# Altium schematic sheet Analog.SchDot(Analog)
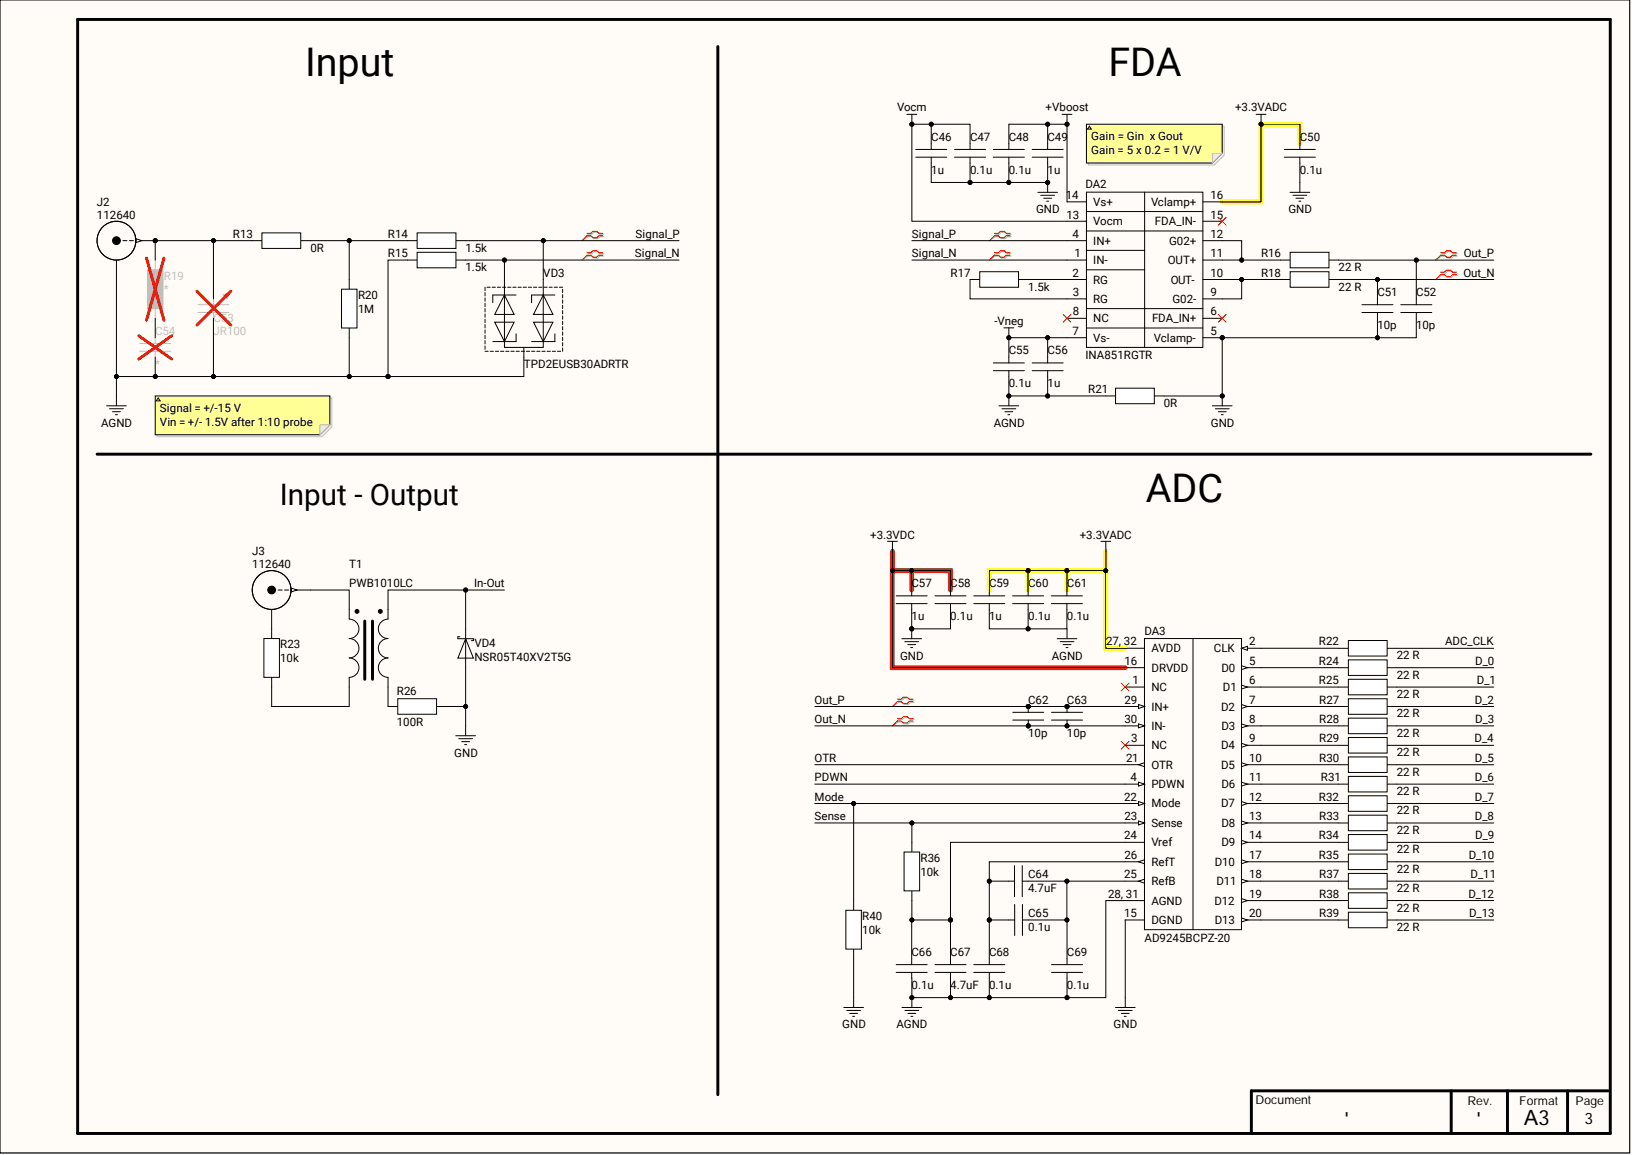
[diagram p0: Analog.SchDot(Analog)]

## Parts
C46  1u  [C0603]
C47  0.1u  [C0603]
C48  0.1u  [C0603]
C49  1u  [C0603]
C50  0.1u  [C0603]
C51  10p  [C0603]
C52  10p  [C0603]
C53  JR100  [Trimmer Capacitors]
C54  (no value)  [C0603]
C55  0.1u  [C0603]
C56  1u  [C0603]
C57  1u  [C0603]
C58  0.1u  [C0603]
C59  1u  [C0603]
C60  0.1u  [C0603]
C61  0.1u  [C0603]
C62  10p  [C0603]
C63  10p  [C0603]
C64  4.7uF  [C0603]
C65  0.1u  [C0603]
C66  0.1u  [C0603]
C67  4.7uF  [C0603]
C68  0.1u  [C0603]
C69  0.1u  [C0603]
DA2  INA851RGTR  [VQFN_16_INA851]
DA3  AD9245BCPZ-20  [LFCSP-32_AD9245]
J2  112640  [112640]
J3  112640  [112640]
R13  0R  [R0603]
R14  1.5k  [R0603]
R15  1.5k  [R0603]
R16  22 R  [R0603]
R17  1.5k  [R0603]
R18  22 R  [R0603]
R19  (no value)  [R0603]
R20  1M  [R0603]
R21  0R  [R0603]
R22  22 R  [R0603]
R23  10k  [R0603]
R24  22 R  [R0603]
R25  22 R  [R0603]
R26  100R  [R0603]
R27  22 R  [R0603]
R28  22 R  [R0603]
R29  22 R  [R0603]
R30  22 R  [R0603]
R31  22 R  [R0603]
R32  22 R  [R0603]
R33  22 R  [R0603]
R34  22 R  [R0603]
R35  22 R  [R0603]
R36  10k  [R0603]
R37  22 R  [R0603]
R38  22 R  [R0603]
R39  22 R  [R0603]
R40  10k  [R0603]
T1  PWB1010LC  [PWB1010]
VD3  TPD2EUSB30ADRTR  [SOT-9X3]
VD4  NSR05T40XV2T5G  [SOD-523-2]

## Nets
+3.3VADC: C50.2 C59.2 C60.2 C61.2 DA2.16(Vclamp+) DA3.27, 32(AVDD)
+3.3VDC: C57.2 C58.2 DA3.16(DRVDD)
+Vboost: C48.2 C49.2 DA2.14(Vs+)
-Vneg: C55.2 C56.2 DA2.7(Vs-)
AGND: C53.2 C54.2 C55.1 C56.1 C59.1 C60.1 C61.1 C66.1 C67.2 C68.1 C69.1 DA3.28, 31(AGND) J2.2 R15.1 R20.1 R21.1 VD3.3
GND: C46.1 C47.1 C48.1 C49.1 C50.1 C51.2 C52.2 C57.1 C58.1 DA2.5(Vclamp-) DA3.15(DGND) R21.2 R26.2 R40.1 VD4.1
Vocm: C46.2 C47.2 DA2.13(Vocm)
ADC_CLK: R22.2
D_0: R24.2
D_1: R25.2
D_2: R27.2
D_3: R28.2
D_4: R29.2
D_5: R30.2
D_6: R31.2
D_7: R32.2
D_8: R33.2
D_9: R34.2
D_10: R35.2
D_11: R37.2
D_12: R38.2
D_13: R39.2
In-Out: T1.6 VD4.2
Mode: DA3.22(Mode) R40.2
OTR: DA3.21(OTR)
Out_N: C51.1 C62.2 C63.2 DA3.30(IN-) R18.2
Out_P: C52.1 C62.1 C63.1 DA3.29(IN+) R16.2
PDWN: DA3.4(PDWN)
Sense: DA3.23(Sense) R36.2
Signal_N: DA2.1(IN-) R15.2 VD3.2
Signal_P: DA2.4(IN+) R14.2 VD3.1
NetC53_1: C53.1 J2.1 R13.1 R19.2
NetC54_1: C54.1 R19.1
NetC64_1: C64.1 C65.2 C68.2 DA3.26(RefT)
NetC64_2: C64.2 C65.1 C69.2 DA3.25(RefB)
NetC66_2: C66.2 C67.1 DA3.24(Vref) R36.1
NetDA2_2: DA2.2(RG) R17.2
NetDA2_3: DA2.3(RG) R17.1
NetDA2_9: DA2.9(G02-) DA2.10(OUT-) R18.1
NetDA2_11: DA2.11(OUT+) DA2.12(G02+) R16.1
NetDA3_2: DA3.2(CLK) R22.1
NetDA3_5: DA3.5(D0) R24.1
NetDA3_6: DA3.6(D1) R25.1
NetDA3_7: DA3.7(D2) R27.1
NetDA3_8: DA3.8(D3) R28.1
NetDA3_9: DA3.9(D4) R29.1
NetDA3_10: DA3.10(D5) R30.1
NetDA3_11: DA3.11(D6) R31.1
NetDA3_12: DA3.12(D7) R32.1
NetDA3_13: DA3.13(D8) R33.1
NetDA3_14: DA3.14(D9) R34.1
NetDA3_17: DA3.17(D10) R35.1
NetDA3_18: DA3.18(D11) R37.1
NetDA3_19: DA3.19(D12) R38.1
NetDA3_20: DA3.20(D13) R39.1
NetJ3_1: J3.1 T1.1
NetJ3_2: J3.2 R23.2
NetR13_2: R13.2 R14.1 R20.2
NetR23_1: R23.1 T1.3
NetR26_1: R26.1 T1.4

Not connected: DA2 6(FDA_IN+) 8(NC) 15(FDA_IN-); DA3 1(NC) 3(NC)

## Library data of the parts
C46 C49 C56 C57 C59 = MLCC: 25V 1uF X7R ±10% 0603 Multilayer Ceramic Capacitors MLCC - SMD/SMT ROHS; Manufacturer: IHHEC(HOLY STONE ENTERPRISE CO., LTD); P/N: C0603X105K025T; Case: 0603; Component Kind: Standard; Component Type: MLCC; ComponentLink1Description: Link; ComponentLink2Description: Datasheet; Value: 1u; Library Name: Scheet.SchLib
  C46 C56 C57 C59: ComponentLink1URL: https://eu.mouser.com/ProductDetail/Murata-Electronics/GCM155C71A105ME38D?qs=QzBtWTOodeV5ddl%2FvWs3LA%3D%3D; ComponentLink2URL: https://eu.mouser.com/datasheet/2/281/1/GCM155C71A105ME38_01A-3142248.pdf
  C49: ComponentLink1URL: https://www.lcsc.com/product-detail/Multilayer-Ceramic-Capacitors-span-style-background-color-ff0-MLCC-span-SMD-SMT_IHHEC-HOLY-STONE-ENTERPRISE-CO-LTD-C0603X105K025T_C559292.html; ComponentLink2URL: https://www.lcsc.com/datasheet/lcsc_datasheet_2304140030_IHHEC-HOLY-STONE-ENTERPRISE-CO---LTD-C0603X105K025T_C559292.pdf
C47 C48 C50 C55 C58 C60 C61 C65 C66 C68 C69 = MLCC: 100V 100nF X7R ±10% 0603 Multilayer Ceramic Capacitors MLCC - SMD/SMT ROHS; Manufacturer: Kyocera AVX; P/N: 06031C104K4T2A; Case: 0603; Component Kind: Standard; Component Type: MLCC; ComponentLink1Description: Link; ComponentLink2Description: Datasheet; Value: 0.1u; Library Name: Scheet.SchLib
C51 C52 C62 C63 = MLCC: 50V 10pF C0G 0603 Multilayer Ceramic Capacitors MLCC - SMD/SMT ROHS; Manufacturer: Kyocera AVX; P/N: 06035A100DAT2A; Case: 0603; Component Kind: Standard; Component Type: MLCC; ComponentLink1Description: Link; ComponentLink2Description: Datasheet; Value: 10p; Library Name: Scheet.SchLib
  C51 C52: ComponentLink1URL: https://eu.mouser.com/ProductDetail/KEMET/C0402C100K5RACTU?qs=Mv7BduZupUgEdPvwNAxLRQ%3D%3D; ComponentLink2URL: https://eu.mouser.com/datasheet/2/447/KEM_C1002_X7R_SMD-3316098.pdf
C53 = JR100: Trimmer/Variable Capacitors 2.0 - 10pF 100volts; Manufacturer: Knowles; Value: 2 pF to 10 pF; Case: JR; Component Kind: Standard; Component Type: Trimmer/Variable Capacitors; ComponentLink1Description: Datasheet; ComponentLink1URL: https://eu.mouser.com/datasheet/2/218/Knowles_Trimmers_Catalogue_web-1774092.pdf; ComponentLink2Description: Link; ComponentLink2URL: https://eu.mouser.com/ProductDetail/Knowles-Voltronics/JR100?qs=Xqu1B%2Fs4Uoag80ukOW5org%3D%3D; P/N: JR100; Library Name: Scheet.SchLib
C54 = MLCC: Cercap smd; Component Kind: Standard; ComponentLink1Description: Link; ComponentLink2Description: Datasheet; Library Name: Scheet.SchLib
C64 C67 = MLCC: 16V 4.7uF X7S ±10% 0603 Multilayer Ceramic Capacitors MLCC - SMD/SMT ROHS; Manufacturer: Murata Electronics; P/N: GRT188C71C475KE13D; Case: 0603; Component Kind: Standard; Component Type: MLCC; ComponentLink1Description: Link; ComponentLink2Description: Datasheet; Value: 4.7uF; Library Name: Scheet.SchLib
  C64: ComponentLink1URL: https://eu.mouser.com/ProductDetail/Murata-Electronics/GRM155R61A106ME11D?qs=xZ%2FP%252Ba9zWqZMJSi57Q8OyA%3D%3D; ComponentLink2URL: https://eu.mouser.com/datasheet/2/281/1/GRM155R61A106ME11_01A-1983731.pdf
DA2 = INA851RGTR: Instrumentation Amplifiers Low-noise (3.2 nV/vHz) high-speed (22 MHz) fully-differential instrumentation amp with OVP (+/-40 V) 16-VQFN -40 to 125; Manufacturer: Texas Instruments; Value: 3.2 nV/vHz. +-40V; Case: VQFN-16; Component Kind: Standard; Component Type: Instrumentation Amplifiers; ComponentLink1Description: Datasheet; ComponentLink1URL: https://www.ti.com/lit/ds/symlink/ina851.pdf?ts=1707581186124&ref_url=https%253A%252F%252Feu.mouser.com%252F; ComponentLink2Description: Link; ComponentLink2URL: https://eu.mouser.com/ProductDetail/Texas-Instruments/INA851RGTR?qs=Y0Uzf4wQF3kbGQkgMC0HWQ%3D%3D; P/N: INA851RGTR; Library Name: Scheet.SchLib
DA3 = AD9245: Analog to Digital Converters - ADC 14-Bit, 20 MSPS/40 MSPS/65 MSPS/80 MSPS 3 V A/D Converter; Manufacturer: Analog Devices Inc.; Value: 14 bit,  20 MS/s; Case: LFCSP-32; Component Kind: Standard; Component Type: Analog to Digital Converters - ADC; ComponentLink1Description: Datasheet; ComponentLink1URL: https://eu.mouser.com/datasheet/2/609/AD9245-3134626.pdf; ComponentLink2Description: Link; ComponentLink2URL: https://eu.mouser.com/ProductDetail/Analog-Devices/AD9245BCPZ-20?qs=%2FtpEQrCGXCyn%2FB%2F5xLQAJw%3D%3D; P/N: AD9245BCPZ-20; Library Name: Scheet.SchLib
J2 J3 = 112640: RF Connectors / Coaxial Connectors BNC PCB END LAUNCH 50 OHM RND BODY; Manufacturer: Amphenol; Value: 50 Ohms; Case: BNC; Component Kind: Standard; Component Type: Jack (Socket); ComponentLink1Description: Datasheet; ComponentLink1URL: https://eu.mouser.com/datasheet/2/18/1/amph_s_a0003617014_1-2261107.pdf; ComponentLink2Description: Link; ComponentLink2URL: https://eu.mouser.com/ProductDetail/Amphenol-RF/112640?qs=g6wJ%252BjiQWOckvE%2FP9L4wAw%3D%3D; P/N: 112640; Library Name: Scheet.SchLib
R13 R21 = SMD Resistor: Res SMD; Manufacturer: VO; P/N: SCR0603J0R; Case: 0603; Component Kind: Standard; ComponentLink1Description: Datasheet; ComponentLink1URL: https://www.lcsc.com/datasheet/lcsc_datasheet_2205131800_VO-SCR0603J0R_C3017805.pdf; ComponentLink2Description: Link; ComponentLink2URL: https://www.lcsc.com/product-detail/Chip-span-style-background-color-ff0-Resistor-span-Surface-Mount_VO-SCR0603J0R_C3017805.html; Value: 0R; Library Name: Scheet.SchLib
R14 R15 R17 = SMD Resistor: Thick Film Resistors - SMD 0402 1K0 0.5%; Manufacturer: UNI-ROYAL(Uniroyal Elec); P/N: TC0325B1501T5F; Case: 0603; Component Kind: Standard; ComponentLink1Description: Datasheet; ComponentLink1URL: https://eu.mouser.com/datasheet/2/210/kamaya_products_RGC__english_20170424_101024-1651573.pdf; ComponentLink2Description: Link; ComponentLink2URL: https://eu.mouser.com/ProductDetail/Kamaya/RGC1-16SC1001DTH?qs=KAq9QXcE8HAz3iV8i32W5A%3D%3D; Value: 1.5k; Library Name: Scheet.SchLib
R16 R18 R22 R24 R25 R27-R35 R37-R39 = SMD Resistor: Res SMD; Manufacturer: VO; P/N: SCR0603J22R; Case: 0603; Component Kind: Standard; ComponentLink1Description: Datasheet; ComponentLink2Description: Link; Value: 22 R; Library Name: Scheet.SchLib
R19 = SMD Resistor: Res SMD; Component Kind: Standard; ComponentLink1Description: Datasheet; ComponentLink2Description: Link; Library Name: Scheet.SchLib
R20 = SMD Resistor: 100mW ±25ppm/℃ ±0.1% 1MΩ 0603 Chip Resistor - Surface Mount ROHS; Manufacturer: Viking Tech; P/N: AR03BTCX1004; Case: 0603; Component Kind: Standard; ComponentLink1Description: Datasheet; ComponentLink2Description: Link; Value: 1M; Library Name: Scheet.SchLib
R23 R36 R40 = SMD Resistor: 100mW Thick Film Resistors 75V ±5% 10kΩ 0603 Chip Resistor - Surface Mount ROHS; Manufacturer: VO; P/N: SCR0603J10K; Case: 0603; Component Kind: Standard; ComponentLink1Description: Datasheet; ComponentLink2Description: Link; Value: 10k; Library Name: Scheet.SchLib
R26 = SMD Resistor: 100mW Thick Film Resistors 75V ±100ppm/℃ ±5% 100Ω 0603 Chip Resistor - Surface Mount ROHS; Manufacturer: RALEC; P/N: RTT03101JTP; Case: 0603; Component Kind: Standard; ComponentLink1Description: Datasheet; ComponentLink2Description: Link; Value: 100R; Library Name: Scheet.SchLib
T1 = PWB1010L: Audio Transformers / Signal Transformers PWB Wideband RF 1:1 0.0035-125 MHz; Manufacturer: Coilcraft; Value: 3.5 kHz to 125 MHz; Component Kind: Standard; ComponentLink1Description: Datasheet; ComponentLink1URL: https://eu.mouser.com/datasheet/2/597/pwb-463529.pdf; ComponentLink2Description: Link; ComponentLink2URL: https://eu.mouser.com/ProductDetail/Coilcraft/PWB1010LC?qs=ZYnrCdKdyeesEVMGru6ImA%3D%3D; P/N: PWB1010LC; Library Name: Scheet.SchLib
VD3 = TPD2EUSB30ADRTR: ESD Suppressors / TVS Diodes 2 Channel ESD/ Surge Solution; Manufacturer: Texas Instruments; Value: 2 Channel, 4.5 V; Case: SOT-9X3; Component Kind: Standard; Component Type: ESD Suppressors / TVS Diodes; ComponentLink1Description: Datasheet; ComponentLink1URL: https://www.ti.com/lit/ds/symlink/tpd2eusb30.pdf?ts=1708175608995&ref_url=https%253A%252F%252Feu.mouser.com%252F; ComponentLink2Description: Link; ComponentLink2URL: https://eu.mouser.com/ProductDetail/Texas-Instruments/TPD2EUSB30ADRTR?qs=O1HRStiETCgOfMa6oTfOlg%3D%3D; P/N: TPD2EUSB30ADRTR; Library Name: Scheet.SchLib
VD4 = NSR05T40XV2T5G: Schottky Diodes & Rectifiers TRENCH SCHOTTKY TI BARRIER FOR BOOST CONVERTERS LOW POWER; Manufacturer: onsemi; Value: 500 mA; Case: SOD-523-2; Component Kind: Standard; Component Type: Schottky Diodes & Rectifiers; ComponentLink1Description: Datasheet; ComponentLink1URL: https://www.mouser.de/datasheet/2/308/1/NSR05T40XV2_D-2318283.pdf; ComponentLink2Description: Link; ComponentLink2URL: https://www.mouser.de/ProductDetail/onsemi/NSR05T40XV2T5G?qs=8hV9pjh0QhPa2G06GHkD%2FQ%3D%3D; Library Name: Diodes.SchLib; P/N: NSR05T40XV2T5G
